# Altium schematic sheet p2_mcu_ble.SchDoc(p2_mcu_ble)
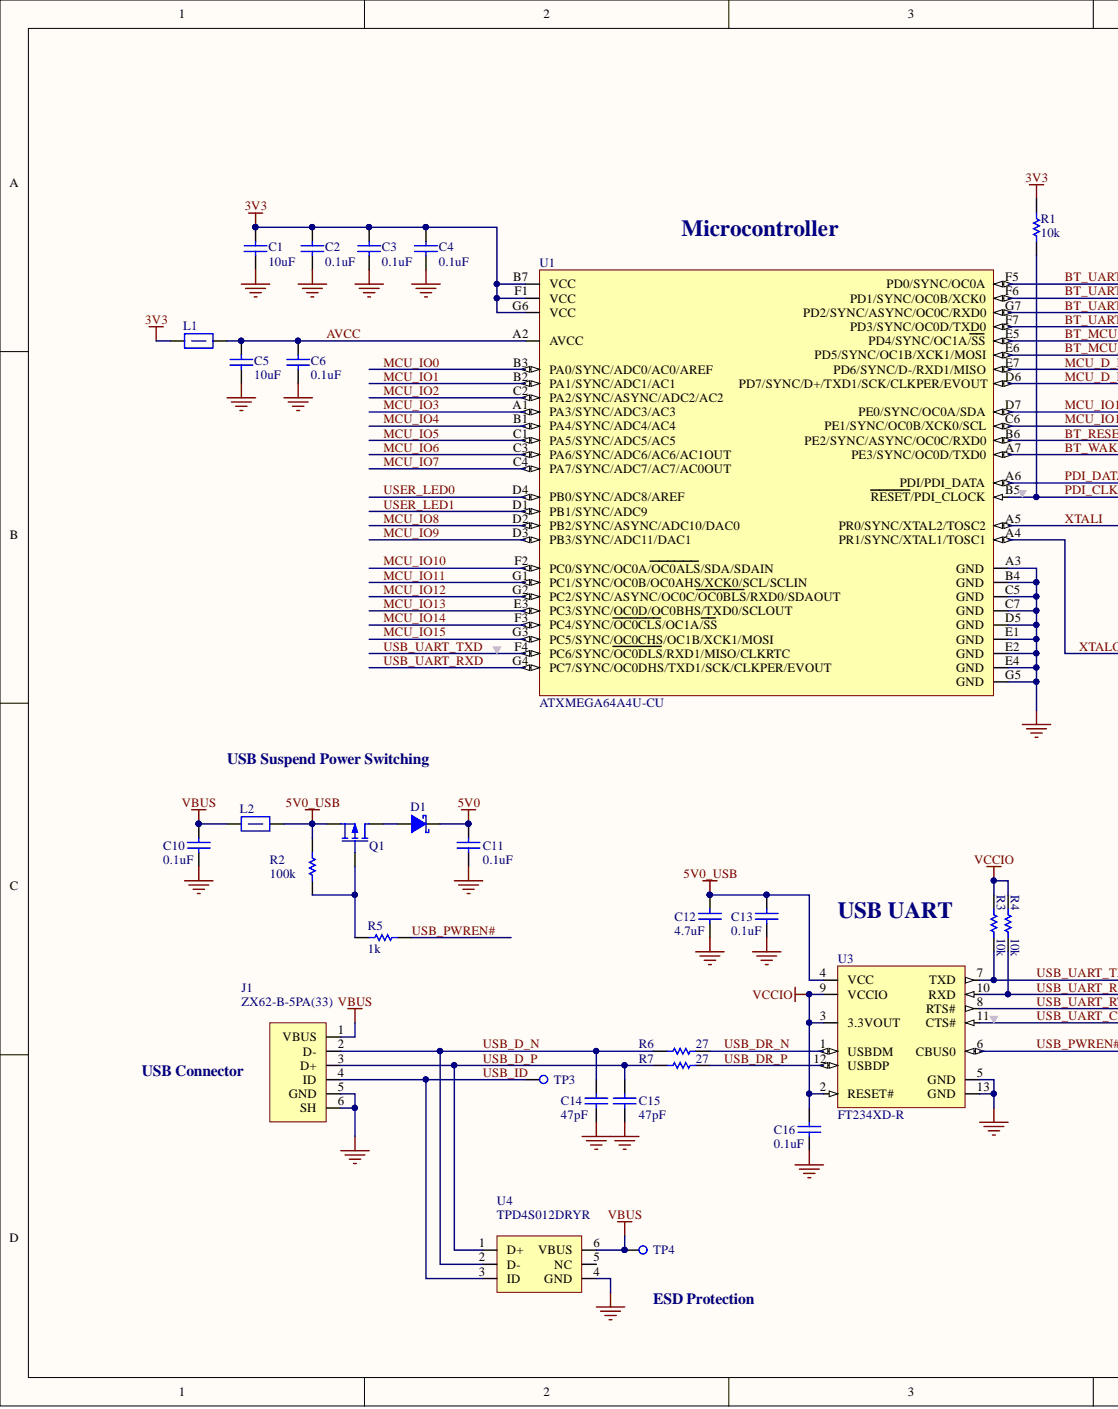
[diagram p0: p2_mcu_ble.SchDoc, left]
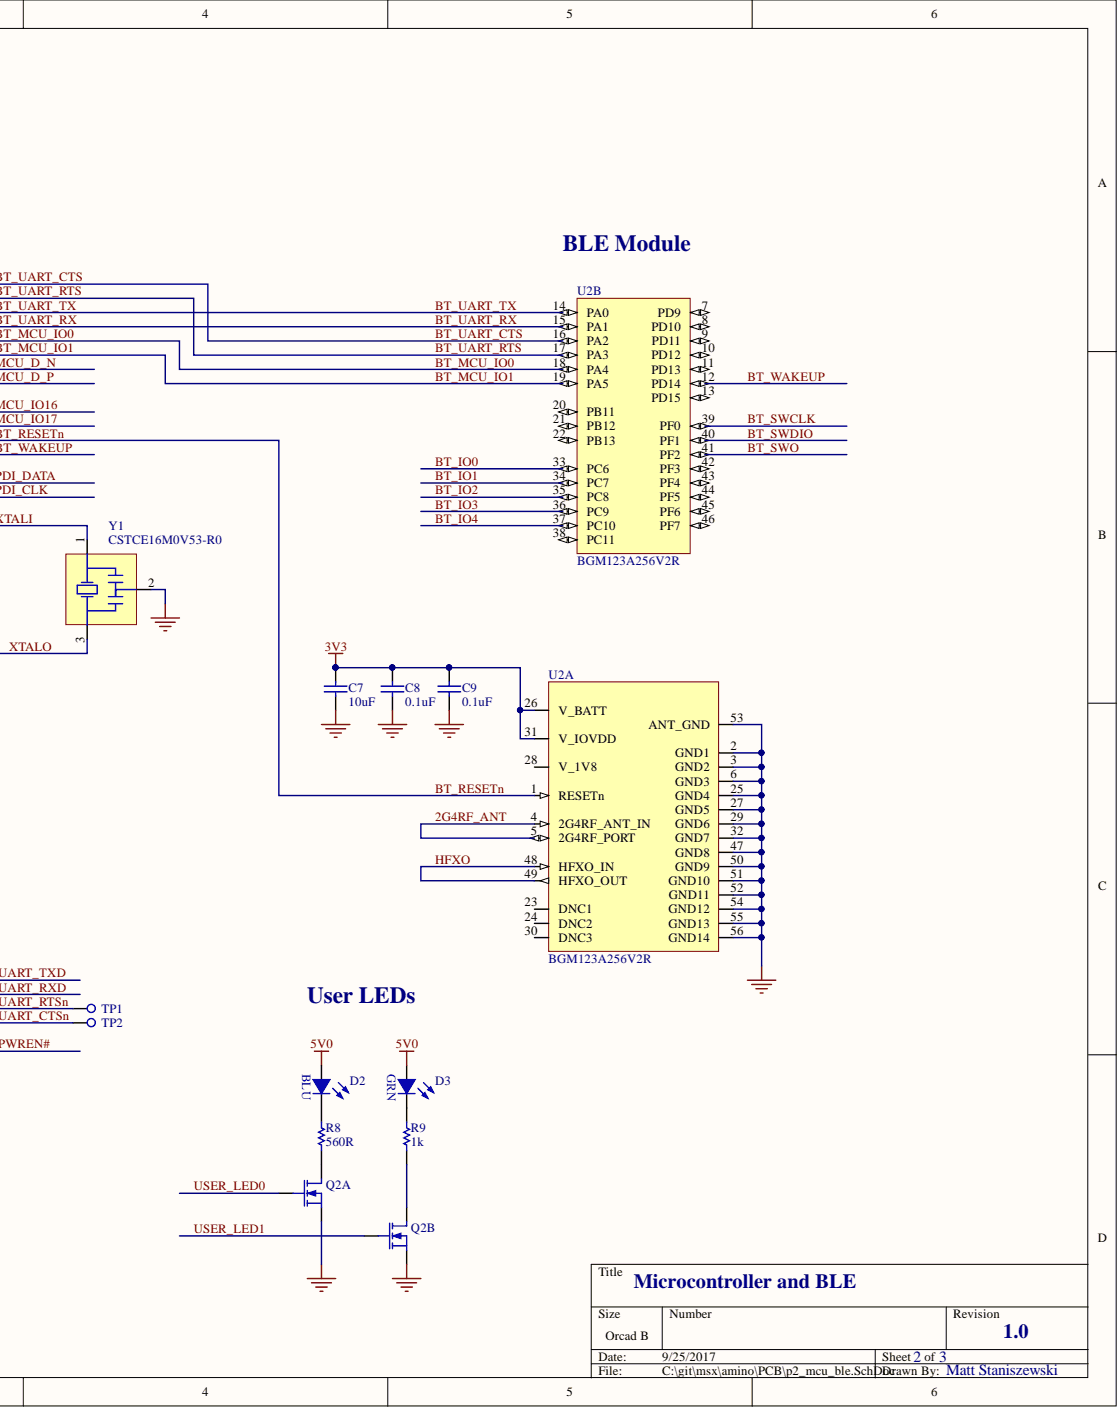
[diagram p1: p2_mcu_ble.SchDoc, right]

## Parts
C1  10uF  [C0603]
C2  0.1uF  [C0201]
C3  0.1uF  [C0201]
C4  0.1uF  [C0201]
C5  10uF  [C0603]
C6  0.1uF  [C0201]
C7  10uF  [C0603]
C8  0.1uF  [C0201]
C9  0.1uF  [C0201]
C10  0.1uF  [C0201]
C11  0.1uF  [C0201]
C12  4.7uF  [C0603]
C13  0.1uF  [C0201]
C14  47pF  [C0201]
C15  47pF  [C0201]
C16  0.1uF  [C0201]
D1  PMEG2020EPK,315  [NXP_DFN1608D-2]  (value not drawn)
D2  5988091107F  [LED0603]  (value not drawn)
D3  5988070107F  [LED0603]  (value not drawn)
J1  ZX62-B-5PA(33)  [HRS_MICRO_USB]
L1  BLM15PX601SN1D  [L0402]  (value not drawn)
L2  BLM15PX601SN1D  [L0402]  (value not drawn)
Q1  DMP21D0UFB4-7B  [DIO_X2-DFN1006-3]  (value not drawn)
Q2  PMDXB600UNE  [NXP_DFN1010B-6]  (value not drawn)  (parts A,B)
R1  10k  [R0201]
R2  100k  [R0201]
R3  10k  [R0201]
R4  10k  [R0201]
R5  1k  [R0201]
R6  27  [R0201]
R7  27  [R0201]
R8  560R  [R0201]
R9  1k  [R0201]
TP1  TP SMT 0.5MM  [TP_SMT_0.5MM]  (value not drawn)
TP2  TP SMT 0.5MM  [TP_SMT_0.5MM]  (value not drawn)
TP3  TP SMT 0.5MM  [TP_SMT_0.5MM]  (value not drawn)
TP4  TP SMT 0.5MM  [TP_SMT_0.5MM]  (value not drawn)
U1  ATXMEGA64A4U-CU  [MCP_49C2]
U2  BGM123A256V2R  [SIL_BGM123]  (parts A,B)
U3  FT234XD-R  [FTD_DFN12]
U4  TPD4S012DRYR  [TI_DRY]
Y1  CSTCE16M0V53-R0  [MUR_CSTCE_V]

## Nets
3V3: C1.2 C2.2 C3.2 C4.2 C7.2 C8.2 C9.2 L1.1 R1.2 U1.B7(VCC) U1.F1(VCC) U1.G6(VCC) U2.26(V_BATT) U2.31(V_IOVDD)
5V0: C11.2 D1.1 D2.2 D3.2
5V0_USB: C12.2 C13.2 L2.2 Q1.2 R2.2 U3.4(VCC)
GND: C1.1 C2.1 C3.1 C4.1 C5.1 C6.1 C7.1 C8.1 C9.1 C10.1 C11.1 C12.1 C13.1 C14.1 C15.1 C16.1 J1.5(GND) J1.6(SH) Q2.1 Q2.4 U1.A3(GND) U1.B4(GND) U1.C5(GND) U1.C7(GND) U1.D5(GND) U1.E1(GND) U1.E2(GND) U1.E4(GND) U1.G5(GND) U2.2(GND1) U2.3(GND2) U2.6(GND3) U2.25(GND4) U2.27(GND5) U2.29(GND6) U2.32(GND7) U2.47(GND8) U2.50(GND9) U2.51(GND10) U2.52(GND11) U2.53(ANT_GND) U2.54(GND12) U2.55(GND13) U2.56(GND14) U3.5(GND) U3.13(GND) U4.4(GND) Y1.2
VBUS: C10.2 J1.1(VBUS) L2.1 TP4.1 U4.6(VBUS)
VCCIO: C16.2 R3.2 R4.2 U3.2(RESET#) U3.3(3.3VOUT) U3.9(VCCIO)
2G4RF_ANT: U2.4(2G4RF_ANT_IN) U2.5(2G4RF_PORT)
AVCC: C5.2 C6.2 L1.2 U1.A2(AVCC)
BT_IO0: U2.33(PC6)
BT_IO1: U2.34(PC7)
BT_IO2: U2.35(PC8)
BT_IO3: U2.36(PC9)
BT_IO4: U2.37(PC10)
BT_MCU_IO0: U1.E5(PD4/SYNC/OC1A/SS) U2.18(PA4)
BT_MCU_IO1: U1.E6(PD5/SYNC/OC1B/XCK1/MOSI) U2.19(PA5)
BT_RESETn: U1.B6(PE2/SYNC/ASYNC/OC0C/RXD0) U2.1(RESETn)
BT_SWCLK: U2.39(PF0)
BT_SWDIO: U2.40(PF1)
BT_SWO: U2.41(PF2)
BT_UART_CTS: U1.F5(PD0/SYNC/OC0A) U2.16(PA2)
BT_UART_RTS: U1.F6(PD1/SYNC/OC0B/XCK0) U2.17(PA3)
BT_UART_RX: U1.F7(PD3/SYNC/OC0D/TXD0) U2.15(PA1)
BT_UART_TX: U1.G7(PD2/SYNC/ASYNC/OC0C/RXD0) U2.14(PA0)
BT_WAKEUP: U1.A7(PE3/SYNC/OC0D/TXD0) U2.12(PD14)
HFXO: U2.48(HFXO_IN) U2.49(HFXO_OUT)
MCU_D_N: U1.E7(PD6/SYNC/D-/RXD1/MISO)
MCU_D_P: U1.D6(PD7/SYNC/D+/TXD1/SCK/CLKPER/EVOUT)
MCU_IO0: U1.B3(PA0/SYNC/ADC0/AC0/AREF)
MCU_IO1: U1.B2(PA1/SYNC/ADC1/AC1)
MCU_IO2: U1.C2(PA2/SYNC/ASYNC/ADC2/AC2)
MCU_IO3: U1.A1(PA3/SYNC/ADC3/AC3)
MCU_IO4: U1.B1(PA4/SYNC/ADC4/AC4)
MCU_IO5: U1.C1(PA5/SYNC/ADC5/AC5)
MCU_IO6: U1.C3(PA6/SYNC/ADC6/AC6/AC1OUT)
MCU_IO7: U1.C4(PA7/SYNC/ADC7/AC7/AC0OUT)
MCU_IO8: U1.D2(PB2/SYNC/ASYNC/ADC10/DAC0)
MCU_IO9: U1.D3(PB3/SYNC/ADC11/DAC1)
MCU_IO10: U1.F2(PC0/SYNC/OC0A/OC0ALS/SDA/SDAIN)
MCU_IO11: U1.G1(PC1/SYNC/OC0B/OC0AHS/XCK0/SCL/SCLIN)
MCU_IO12: U1.G2(PC2/SYNC/ASYNC/OC0C/OC0BLS/RXD0/SDAOUT)
MCU_IO13: U1.E3(PC3/SYNC/OC0D/OC0BHS/TXD0/SCLOUT)
MCU_IO14: U1.F3(PC4/SYNC/OC0CLS/OC1A/SS)
MCU_IO15: U1.G3(PC5/SYNC/OC0CHS/OC1B/XCK1/MOSI)
MCU_IO16: U1.D7(PE0/SYNC/OC0A/SDA)
MCU_IO17: U1.C6(PE1/SYNC/OC0B/XCK0/SCL)
PDI_CLK: R1.1 U1.B5(RESET/PDI_CLOCK)
PDI_DATA: U1.A6(PDI/PDI_DATA)
USB_D_N: C14.2 J1.2(D-) R6.1 U4.2(D-)
USB_D_P: C15.2 J1.3(D+) R7.1 U4.1(D+)
USB_DR_N: R6.2 U3.1(USBDM)
USB_DR_P: R7.2 U3.12(USBDP)
USB_ID: J1.4(ID) TP3.1 U4.3(ID)
USB_PWREN#: R5.2 U3.6(CBUS0)
USB_UART_CTSn: TP2.1 U3.11(CTS#)
USB_UART_RTSn: TP1.1 U3.8(RTS#)
USB_UART_RXD: R4.1 U1.G4(PC7/SYNC/OC0DHS/TXD1/SCK/CLKPER/EVOUT) U3.10(RXD)
USB_UART_TXD: R3.1 U1.F4(PC6/SYNC/OC0DLS/RXD1/MISO/CLKRTC) U3.7(TXD)
USER_LED0: Q2.2 U1.D4(PB0/SYNC/ADC8/AREF)
USER_LED1: Q2.5 U1.D1(PB1/SYNC/ADC9)
XTALI: U1.A5(PR0/SYNC/XTAL2/TOSC2) Y1.1
XTALO: U1.A4(PR1/SYNC/XTAL1/TOSC1) Y1.3
NetD1_2: D1.2 Q1.3
NetD2_1: D2.1 R8.2
NetD3_1: D3.1 R9.2
NetQ1_1: Q1.1 R2.1 R5.1
NetQ2_3: Q2.3 R9.1
NetQ2_6: Q2.6 R8.1

Not connected: U2 7(PD9) 8(PD10) 9(PD11) 10(PD12) 11(PD13) 13(PD15) 20(PB11) 21(PB12) 22(PB13) 23(DNC1) 24(DNC2) 28(V_1V8) 30(DNC3) 38(PC11) 42(PF3) 43(PF4) 44(PF5) 45(PF6) 46(PF7); U4 5(NC)

## Library data of the parts
C1 C5 C7 = 10uF 25V 0603: CAP CER 10UF 25V X5R 0603; Manufacturer Part Number: GRM188R61E106MA73D; Manufacturer: Murata; Tolerance: 20%; Width: 0.031inch; Weight: 0.000222oz; Voltage Rating (DC): 25V; Voltage Rating: 25V; Voltage: 25V; Thickness: 0.031inch; Termination: SMD/SMT; Supplier Part Number 1: 490-7202-1-ND; Supplier 1: Digi-Key; Stock 1: 1088965; REACH SVHC: No SVHC; Pricing 1: 1=0.41, 10=0.285, 100=0.1708, 500=0.1281, 1000=0.1082 (USD); Packaging: Tape and Reel; Package Quantity: 1; Number of Pins: 2; Mount: Surface Mount; Min Operating Temperature: -55°C; Max Operating Temperature: 85°C; Library Name: Capacitors.SchLib; Length: 1.6mm; Lead Free: Lead Free; Height: 0.8mm; Dielectric: X5R; Depth: 0.8mm; Composition: Ceramic; ComponentLink5URL: https://datasheet.ciiva.com/14906/partnumbering-e-01-14906431.pdf; ComponentLink5Description: https://datasheet.ciiva.com/14906/partnumbering-e-01-14906431.pdf; ComponentLink4URL: https://datasheet.ciiva.com/14906/partnumbering-e-01-14906429.pdf; ComponentLink4Description: https://datasheet.ciiva.com/14906/partnumbering-e-01-14906429.pdf; ComponentLink3URL: https://datasheet.ciiva.com/22776/c02e-22776427.pdf; ComponentLink3Description: https://datasheet.ciiva.com/22776/c02e-22776427.pdf; ComponentLink2URL: https://datasheet.ciiva.com/22776/608985-22776426.pdf; ComponentLink2Description: https://datasheet.ciiva.com/22776/608985-22776426.pdf; ComponentLink1URL: https://datasheet.ciiva.com/22619/c02e-22619674.pdf; ComponentLink1Description: https://datasheet.ciiva.com/22619/c02e-22619674.pdf; Component Kind: Standard; Case Code (Metric): 1608; Case Code (Imperial): 0603; Case/Package: 0603; Capacitance: 10uF
C2-C4 C6 C8-C11 C13 C16 = 0.1uF 16V 0201: CAP CER 0.1UF 16V X5R 0201; Manufacturer Part Number: GRM033R61C104ME84D; Manufacturer: Murata; Tolerance: 20%; Capacitance: 1E-07f; Width: 0.3mm; Weight: 1.2E-05oz; Voltage Rating (DC): 16V; Voltage Rating: 16V; Thickness: 0.013inch; Termination: SMD/SMT; Supplier Part Number 1: 490-9986-1-ND; Supplier 1: Digi-Key; Stock 1: 660567; Pricing 1: 1=0.1, 10=0.029, 100=0.013, 500=0.0093, 1000=0.0073, 2500=0.0067, 5000=0.0061 (USD); Packaging: Tape and Reel; Package Quantity: 15000; Min Operating Temperature: -55°C; Max Operating Temperature: 85°C; Library Name: Capacitors.SchLib; Length: 0.024inch; Height: 0.3mm; Dielectric: X5R; ComponentLink1URL: http://www.mouser.com/ds/2/281/c02e-2905.pdf; ComponentLink1Description: http://www.mouser.com/ds/2/281/c02e-2905.pdf; Component Kind: Standard; Case Code (Metric): 0603; Case Code (Imperial): 0201; Case/Package: 0201
C12 = 4.7uF 16V 0603: CAP CER 4.7UF 16V X5R 0603; Manufacturer Part Number: GRM188R61C475KAAJD; Manufacturer: Murata; Tolerance: 10%; Material: Ceramic; Width: 0.8mm; Weight: 0.000222oz; Voltage Rating (DC): 16V; Voltage Rating: 16V; Thickness: 0.037inch; Termination: SMD/SMT; Supplier Part Number 1: 490-10481-1-ND; Supplier 1: Digi-Key; Stock 1: 135824; REACH SVHC: No SVHC; Pricing 1: 1=0.35, 10=0.238, 100=0.1351, 500=0.0953, 1000=0.0834 (USD); Packaging: Tape and Reel; Package Quantity: 4000; Min Operating Temperature: -55°C; Max Operating Temperature: 85°C; Library Name: Capacitors.SchLib; Length: 1.6mm; Lead Free: Lead Free; Height: 0.8mm; Dielectric: X5R; Depth: 0.8mm; ComponentLink1URL: https://datasheet.ciiva.com/14813/595grm188r61c475kaaj23-14813668.pdf; ComponentLink1Description: https://datasheet.ciiva.com/14813/595grm188r61c475kaaj23-14813668.pdf; Component Kind: Standard; Case Code (Metric): 1608; Case Code (Imperial): 0603; Case/Package: 0603; Capacitance: 4.7uF
C14 C15 = 47pF 25V 0201: CAP CER 47PF 25V C0G/NP0 0201; Manufacturer Part Number: GRM0335C1E470FA01D; Manufacturer: Murata; Tolerance: 1%; Width: 0.012inch; Voltage Rating (DC): 25V; Voltage Rating: 25V; Thickness: 0.013inch; Termination: SMD/SMT; Supplier Part Number 1: 490-11298-1-ND; Supplier 1: Digi-Key; Stock 1: 97008; Pricing 1: 1=0.1, 10=0.019, 100=0.0087, 500=0.0062, 1000=0.0049, 2500=0.0044, 5000=0.0041 (USD); Packaging: Tape and Reel; Package Quantity: 15000; Min Operating Temperature: -55°C; Max Operating Temperature: 125°C; Library Name: Capacitors.SchLib; Length: 0.024inch; ComponentLink1URL: https://datasheet.ciiva.com/29538/partnumbering-e-01-29538333.pdf; ComponentLink1Description: https://datasheet.ciiva.com/29538/partnumbering-e-01-29538333.pdf; Component Kind: Standard; Case Code (Metric): 0603; Case Code (Imperial): 0201; Case/Package: 0201; Capacitance: 47pF
D1 = PMEG2020EPK,315: DIODE SCHOTTKY 20V 2A 2DFN; Manufacturer: NXP Semiconductors; Reverse Recovery Time: 5ns; Peak Reverse Current: 70uA; Peak Non-Repetitive Surge Current: 5A; Natural Thermal Resistance: 20°C/W; Max Power Dissipation: 1.565W; Forward Voltage: 395mV; Element Configuration: Single; Supplier Part Number 1: 1727-1338-1-ND; Supplier 1: Digi-Key; Stock 1: 146420; Reverse Voltage (DC): 20V; Radiation Hardening: No; Pricing 1: 1=0.51, 10=0.387, 100=0.2411, 500=0.165, 1000=0.1269 (USD); Packaging: Tape and Reel; Package Quantity: 8000; Number of Pins: 2; Mount: Surface Mount; Min Operating Temperature: -55°C; Max Repetitive Reverse Voltage (Vrrm): 20V; Max Operating Temperature: 150°C; Max Forward Surge Current (Ifsm): 5A; Manufacturer Part Number: PMEG2020EPK,315; Library Name: Diodes.SchLib; Forward Current: 2A; ComponentLink4URL: https://datasheet.ciiva.com/25648/pmeg2020epk-25648600.pdf; ComponentLink4Description: https://datasheet.ciiva.com/25648/pmeg2020epk-25648600.pdf; ComponentLink3URL: https://datasheet.ciiva.com/24111/pmeg2020epk-268880-24111063.pdf; ComponentLink3Description: https://datasheet.ciiva.com/24111/pmeg2020epk-268880-24111063.pdf; ComponentLink2URL: https://datasheet.ciiva.com/29592/pmeg2020epk-29592901.pdf; ComponentLink2Description: https://datasheet.ciiva.com/29592/pmeg2020epk-29592901.pdf; ComponentLink1URL: https://datasheet.ciiva.com/29592/pmeg2020epk-29592900.pdf; ComponentLink1Description: https://datasheet.ciiva.com/29592/pmeg2020epk-29592900.pdf; Component Kind: Standard; Case/Package: SMD/SMT; Average Rectified Current: 2A
D2 = Blue 0603 LED: LED BLUE CLEAR 0603 SMD; Manufacturer Part Number: 598-8091-107F; Manufacturer: Dialight; Wavelength: 475nm; Viewing Angle: 140°; Test Current: 20mA; Power Dissipation: 70mW; Number of LEDs: 1; Luminous Intensity: 140mcd; Lens Style: Clear,Diffused,Colorless,Rectangular; Lens Colour: Clear,Colorless; Forward Voltage: 3.2V; Dominant Wavelength: 470nm; Width: 0.8mm; Supplier Part Number 1: 350-2037-1-ND; Supplier 1: Digi-Key; Stock 1: 42042; REACH SVHC: No SVHC; Radiation Hardening: No; Pricing 1: 1=0.75, 10=0.583, 25=0.4864, 100=0.4189, 250=0.374, 500=0.3216, 1000=0.2483 (USD); Packaging: Tape and Reel; Package Quantity: 4000; Number of Pins: 2; Number of Elements: 1; Mount: Surface Mount; Min Operating Temperature: -30°C; Max Operating Temperature: 100°C; Library Name: Diodes.SchLib; Lens Transparency: Clear; Length: 1.6mm; Lead Free: Lead Free; Illumination Colour: Blue; Height: 0.7mm; Forward Current: 20mA; Depth: 0.8mm; ComponentLink9URL: https://datasheet.ciiva.com/16400/smt-selector-guide-16400478.pdf; ComponentLink9Description: https://datasheet.ciiva.com/16400/smt-selector-guide-16400478.pdf; ComponentLink8URL: https://datasheet.ciiva.com/14603/70081992-14603963.pdf; ComponentLink8Description: https://datasheet.ciiva.com/14603/70081992-14603963.pdf; ComponentLink7URL: https://datasheet.ciiva.com/7970/25658-7970182.pdf; ComponentLink7Description: https://datasheet.ciiva.com/7970/25658-7970182.pdf; ComponentLink6URL: https://datasheet.ciiva.com/2111/598-series-0603-pkg-2111558.pdf; ComponentLink6Description: https://datasheet.ciiva.com/2111/598-series-0603-pkg-2111558.pdf; ComponentLink5URL: https://datasheet.ciiva.com/30240/mdei5980603-30240533.pdf; ComponentLink5Description: https://datasheet.ciiva.com/30240/mdei5980603-30240533.pdf; ComponentLink4URL: https://datasheet.ciiva.com/15965/mdei5980603-15965445.pdf; ComponentLink4Description: https://datasheet.ciiva.com/15965/mdei5980603-15965445.pdf; ComponentLink3URL: https://datasheet.ciiva.com/2111/598-series-0603-pkg-2111557.pdf; ComponentLink3Description: https://datasheet.ciiva.com/2111/598-series-0603-pkg-2111557.pdf; ComponentLink2URL: https://datasheet.ciiva.com/2111/598-series-0603-pkg-2111556.pdf; ComponentLink2Description: https://datasheet.ciiva.com/2111/598-series-0603-pkg-2111556.pdf; ComponentLink1URL: https://datasheet.ciiva.com/15118/getdatasheetpartid-194139-15118048.pdf; ComponentLink1Description: https://datasheet.ciiva.com/15118/getdatasheetpartid-194139-15118048.pdf; Component Kind: Standard; Colour: Blue; Case Code (Metric): 1608; Case Code (Imperial): 0603; Case/Package: 0603
D3 = Green 0603 LED: LED GREEN CLEAR 0603 SMD; Manufacturer Part Number: 598-8070-107F; Manufacturer: Dialight; Wavelength: 570nm; Viewing Angle: 140°; Test Current: 20mA; Power Dissipation: 60mW; Number of LEDs: 1; Luminous Intensity: 20mcd; Lens Style: Clear,Diffused,Colorless,Rectangular; Forward Voltage: 2V; Dominant Wavelength: 568nm; Width: 0.8mm; Supplier Part Number 1: 350-2035-1-ND; Supplier 1: Digi-Key; Stock 1: 147636; REACH SVHC: No SVHC; Radiation Hardening: No; Pricing 1: 1=0.45, 10=0.327, 25=0.2504, 100=0.1634, 250=0.1198, 500=0.1016, 1000=0.0835 (USD); Packaging: Tape and Reel; Package Quantity: 4000; Number of Pins: 2; Number of Elements: 1; Mount: Surface Mount; Min Operating Temperature: -30°C; Max Operating Temperature: 100°C; Library Name: Diodes.SchLib; Lens Colour: Clear; Length: 1.6mm; Lead Free: Lead Free; Illumination Colour: Green; Height: 0.7mm; Forward Current: 20mA; Depth: 0.8mm; ComponentLink13URL: https://datasheet.ciiva.com/16400/smt-selector-guide-16400463.pdf; ComponentLink13Description: https://datasheet.ciiva.com/16400/smt-selector-guide-16400463.pdf; ComponentLink12URL: https://datasheet.ciiva.com/22749/1484480-22749228.pdf; ComponentLink12Description: https://datasheet.ciiva.com/22749/1484480-22749228.pdf; ComponentLink11URL: https://datasheet.ciiva.com/15117/getdatasheetpartid-146438-15117836.pdf; ComponentLink11Description: https://datasheet.ciiva.com/15117/getdatasheetpartid-146438-15117836.pdf; ComponentLink10URL: https://datasheet.ciiva.com/30188/mdei5980603-30188139.pdf; ComponentLink10Description: https://datasheet.ciiva.com/30188/mdei5980603-30188139.pdf; ComponentLink9URL: https://datasheet.ciiva.com/15965/mdei5980603-15965419.pdf; ComponentLink9Description: https://datasheet.ciiva.com/15965/mdei5980603-15965419.pdf; ComponentLink8URL: https://datasheet.ciiva.com/14605/70081990-14605530.pdf; ComponentLink8Description: https://datasheet.ciiva.com/14605/70081990-14605530.pdf; ComponentLink7URL: https://datasheet.ciiva.com/2110/598-series-0603-pkg-2110167.pdf; ComponentLink7Description: https://datasheet.ciiva.com/2110/598-series-0603-pkg-2110167.pdf; ComponentLink6URL: https://datasheet.ciiva.com/2110/598-series-0603-pkg-2110166.pdf; ComponentLink6Description: https://datasheet.ciiva.com/2110/598-series-0603-pkg-2110166.pdf; ComponentLink5URL: https://datasheet.ciiva.com/1243/1484480-1243894.pdf; ComponentLink5Description: https://datasheet.ciiva.com/1243/1484480-1243894.pdf; ComponentLink4URL: https://datasheet.ciiva.com/2110/598-series-0603-pkg-2110165.pdf; ComponentLink4Description: https://datasheet.ciiva.com/2110/598-series-0603-pkg-2110165.pdf; ComponentLink3URL: https://datasheet.ciiva.com/1243/1484480-1243893.pdf; ComponentLink3Description: https://datasheet.ciiva.com/1243/1484480-1243893.pdf; ComponentLink2URL: https://datasheet.ciiva.com/11774/0900766b80ae2975-11774257.pdf; ComponentLink2Description: https://datasheet.ciiva.com/11774/0900766b80ae2975-11774257.pdf; ComponentLink1URL: https://datasheet.ciiva.com/4688/598-selectorguide-18902-4688880.pdf; ComponentLink1Description: https://datasheet.ciiva.com/4688/598-selectorguide-18902-4688880.pdf; Component Kind: Standard; Colour: Green; Case Code (Metric): 1608; Case Code (Imperial): 0603; Case/Package: 0603
J1 = USB Micro B: CONN RCPT USB MICRO B SMD R/A; Manufacturer: Hirose; Number of Contacts: 5; Mating Cycles: 10000; Gender: Receptacle; Current Rating: 1.8A; Supplier Part Number 1: H125270CT-ND; Supplier 1: Digi-Key; Stock 1: 63782; REACH SVHC: Unknown; Pricing 1: 1=0.84, 10=0.792, 25=0.7128, 50=0.6336, 100=0.6072, 250=0.5544, 500=0.528, 1000=0.4356 (USD); Packaging: Tape and Reel; Orientation: Right Angle; Manufacturer Part Number: ZX62-B-5PA(33); Library Name: Connectors.SchLib; Lead Free: Lead Free; Contact Plating: Gold; Contact Material: Copper; Connector Type: USB; ComponentLink1URL: http://www.farnell.com/datasheets/2060515.pdf; ComponentLink1Description: http://www.farnell.com/datasheets/2060515.pdf; Component Kind: Standard
L1 L2 = BLM15PX601SN1D: FERRITE BEAD 600 OHM 0402 1LN; Manufacturer: Murata; Tolerance: 25%; Test Frequency: 100MHz; Number of Lines: 1; Max DC Current: 900mA; Material: Steel; Impedance: 600R; DC Resistance (DCR): 230mR; Width: 0.5mm; Termination: SMD/SMT; Supplier Part Number 1: 490-9657-1-ND; Supplier 1: Digi-Key; Stock 1: 156023; Pricing 1: 1=0.15, 10=0.123, 25=0.0956, 50=0.0792, 100=0.0682, 250=0.0546, 500=0.0491, 1000=0.0409, 5000=0.0382 (USD); Packaging: Tape and Reel; Package Quantity: 10000; Number of Pins: 2; Number of Circuits: 1; Min Operating Temperature: -55°C; Max Operating Temperature: 125°C; Max Current Rating: 900mA; Manufacturer Part Number: BLM15PX601SN1D; Library Name: Inductors.SchLib; Length: 1mm; Lead Free: Lead Free; Height: 0.5mm; Current Rating: 900mA; ComponentLink2URL: https://datasheet.ciiva.com/41449/001172217-da-01-en-drossel-0402-600r-1-0x0-5mm-10000st-41449505.pdf; ComponentLink2Description: https://datasheet.ciiva.com/41449/001172217-da-01-en-drossel-0402-600r-1-0x0-5mm-10000st-41449505.pdf; ComponentLink1URL: https://datasheet.ciiva.com/41860/l0110e-41860698.pdf; ComponentLink1Description: https://datasheet.ciiva.com/41860/l0110e-41860698.pdf; Component Kind: Standard; Case Code (Metric): 1005; Case Code (Imperial): 0402; Case/Package: 0402
Q1 = DMP21D0UFB4-7B: MOSFET P-CH 20V 770MA 3DFN; Manufacturer: Diodes; Turn-On Delay Time: 7.1ns; Turn-Off Delay Time: 31.7ns; Rise Time: 8ns; Rds On Max: 495mR; Power Dissipation: 990mW; Number of Elements: 1; Input Capacitance: 80pF; Gate to Source Voltage (Vgs): 8V; Fall Time: 18.5ns; Element Configuration: Single; Drain to Source Resistance: 960mR; Drain to Source Breakdown Voltage: -20V; Continuous Drain Current (ID): 1.17A; Width: 0.65mm; Supplier Part Number 1: DMP21D0UFB4-7BDICT-ND; Supplier 1: Digi-Key; Stock 1: 167177; REACH SVHC: No SVHC; Radiation Hardening: No; Pricing 1: 1=0.4, 10=0.303, 100=0.1886, 500=0.129, 1000=0.0992 (USD); Packaging: Tape and Reel; Package Quantity: 1; Number of Pins: 3; Number of Channels: 1; Mount: Surface Mount; Min Operating Temperature: -55°C; Max Power Dissipation: 990mW; Max Operating Temperature: 150°C; Manufacturer Part Number: DMP21D0UFB4-7B; Library Name: ICs.SchLib; Length: 1.05mm; Lead Free: Lead Free; Height: 0.35mm; Drain to Source Voltage (Vdss): 20V; Contact Plating: Gold; ComponentLink6URL: https://datasheet.ciiva.com/13051/dmp21d0ufb4-13051690.pdf; ComponentLink6Description: https://datasheet.ciiva.com/13051/dmp21d0ufb4-13051690.pdf; ComponentLink5URL: https://datasheet.ciiva.com/30479/0900766b8115c367-30479913.pdf; ComponentLink5Description: https://datasheet.ciiva.com/30479/0900766b8115c367-30479913.pdf; ComponentLink4URL: https://datasheet.ciiva.com/30023/dmp21d0ufb4-30023815.pdf; ComponentLink4Description: https://datasheet.ciiva.com/30023/dmp21d0ufb4-30023815.pdf; ComponentLink3URL: https://datasheet.ciiva.com/6369/dmp21d0ufb4-6369015.pdf; ComponentLink3Description: https://datasheet.ciiva.com/6369/dmp21d0ufb4-6369015.pdf; ComponentLink2URL: https://datasheet.ciiva.com/6369/dmp21d0ufb4-6369014.pdf; ComponentLink2Description: https://datasheet.ciiva.com/6369/dmp21d0ufb4-6369014.pdf; ComponentLink1URL: https://datasheet.ciiva.com/6369/dmp21d0ufb4-6369012.pdf; ComponentLink1Description: https://datasheet.ciiva.com/6369/dmp21d0ufb4-6369012.pdf; Component Kind: Standard; Case/Package: DFN
Q2 = PMDXB600UNE: MOSFET 2N-CH 20V 0.6A 6DFN; Manufacturer: NXP Semiconductors; Threshold Voltage: 700mV; Rds On Max: 620mR; Max Power Dissipation: 265mW; Input Capacitance: 21.3pF; Continuous Drain Current (ID): 600mA; Supplier Part Number 1: 1727-1470-1-ND; Supplier 1: Digi-Key; Stock 1: 27879; REACH SVHC: No SVHC; Pricing 1: 1=0.65, 10=0.552, 100=0.4124, 500=0.324, 1000=0.2504 (USD); Packaging: Tape and Reel; Number of Pins: 6; Min Operating Temperature: -55°C; Max Operating Temperature: 150°C; Manufacturer Part Number: PMDXB600UNE; Library Name: ICs.SchLib; Drain to Source Voltage (Vdss): 20V; ComponentLink3URL: https://datasheet.ciiva.com/29589/pmdxb600une-29589933.pdf; ComponentLink3Description: https://datasheet.ciiva.com/29589/pmdxb600une-29589933.pdf; ComponentLink2URL: https://datasheet.ciiva.com/29589/pmdxb600une-29589932.pdf; ComponentLink2Description: https://datasheet.ciiva.com/29589/pmdxb600une-29589932.pdf; ComponentLink1URL: https://datasheet.ciiva.com/29589/pmdxb600une-29589931.pdf; ComponentLink1Description: https://datasheet.ciiva.com/29589/pmdxb600une-29589931.pdf; Component Kind: Standard; Case/Package: 600
R1 R3 R4 = 10k 0201: RES SMD 10K OHM 1% 1/20W 0201; Manufacturer Part Number: ERJ-1GEF1002C; Manufacturer: Panasonic ECG; Voltage Rating (DC): 15V; Voltage Rating: 25V; Temperature Coefficient: 200ppm/°C; Resistance: 10KR; Power Rating: 50mW; Width: 0.012inch; Tolerance: 1%; Termination: SMD/SMT; Supplier Part Number 1: P10.0KABCT-ND; Supplier 1: Digi-Key; Stock 1: 4709989; REACH SVHC: Unknown; Radiation Hardening: No; Pricing 1: 1=0.1, 10=0.059, 100=0.024, 1000=0.0108, 2500=0.0094, 5000=0.0077 (USD); Packaging: Tape and Reel; Package Quantity: 15000; Number of Terminations: 2; Mount: Surface Mount; Min Operating Temperature: -55°C; Military Standard: Not; Max Power Dissipation: 50mW; Max Operating Temperature: 125°C; Library Name: Resistors.SchLib; Length: 0.6mm; Lead Free: Lead Free; Height: 0.23mm; Depth: 0.3mm; Contact Plating: Tin; Composition: Thick Film; ComponentLink6URL: https://datasheet.ciiva.com/16770/70260683-16770095.pdf; ComponentLink6Description: https://datasheet.ciiva.com/16770/70260683-16770095.pdf; ComponentLink5URL: https://datasheet.ciiva.com/7830/1678796-7830952.pdf; ComponentLink5Description: https://datasheet.ciiva.com/7830/1678796-7830952.pdf; ComponentLink4URL: https://datasheet.ciiva.com/22785/1678796-22785991.pdf; ComponentLink4Description: https://datasheet.ciiva.com/22785/1678796-22785991.pdf; ComponentLink3URL: https://datasheet.ciiva.com/16775/70260683-16775527.pdf; ComponentLink3Description: https://datasheet.ciiva.com/16775/70260683-16775527.pdf; ComponentLink2URL: https://datasheet.ciiva.com/30111/aoa0000ce2-30111653.pdf; ComponentLink2Description: https://datasheet.ciiva.com/30111/aoa0000ce2-30111653.pdf; ComponentLink1URL: https://datasheet.ciiva.com/16427/erj-1gef1002c-16427252.pdf; ComponentLink1Description: https://datasheet.ciiva.com/16427/erj-1gef1002c-16427252.pdf; Component Kind: Standard; Case Code (Metric): 0603; Case Code (Imperial): 0201; Case/Package: 0201
R2 = 100k 0201: RES SMD 100K OHM 1% 1/20W 0201; Manufacturer Part Number: ERJ-1GEF1003C; Manufacturer: Panasonic ECG; Voltage Rating (DC): 15V; Voltage Rating: 25V; Temperature Coefficient: 200ppm/°C; Resistance: 100KR; Power Rating: 50mW; Width: 0.012inch; Tolerance: 1%; Termination: SMD/SMT; Supplier Part Number 1: P100KABCT-ND; Supplier 1: Digi-Key; Stock 1: 4025669; REACH SVHC: Unknown; Radiation Hardening: No; Pricing 1: 1=0.1, 10=0.059, 100=0.024, 1000=0.0108, 2500=0.0094, 5000=0.0077 (USD); Packaging: Tape and Reel; Package Quantity: 1; Number of Terminations: 2; Mount: Surface Mount; Min Operating Temperature: -55°C; Military Standard: Not; Max Power Dissipation: 50mW; Max Operating Temperature: 125°C; Library Name: Resistors.SchLib; Length: 0.6mm; Lead Free: Lead Free; Height: 0.01inch; Depth: 0.3mm; Contact Plating: Tin; Composition: Thick Film; ComponentLink8URL: https://datasheet.ciiva.com/30087/aoa0000ce2-30087131.pdf; ComponentLink8Description: https://datasheet.ciiva.com/30087/aoa0000ce2-30087131.pdf; ComponentLink7URL: https://datasheet.ciiva.com/28514/1694929-28514010.pdf; ComponentLink7Description: https://datasheet.ciiva.com/28514/1694929-28514010.pdf; ComponentLink6URL: https://datasheet.ciiva.com/16782/70260683-16782969.pdf; ComponentLink6Description: https://datasheet.ciiva.com/16782/70260683-16782969.pdf; ComponentLink5URL: https://datasheet.ciiva.com/16205/erj-1gef1003c-16205689.pdf; ComponentLink5Description: https://datasheet.ciiva.com/16205/erj-1gef1003c-16205689.pdf; ComponentLink4URL: https://datasheet.ciiva.com/7830/1678796-7830953.pdf; ComponentLink4Description: https://datasheet.ciiva.com/7830/1678796-7830953.pdf; ComponentLink3URL: https://datasheet.ciiva.com/2439/erj-g-r-e-rev08-2439267.pdf; ComponentLink3Description: https://datasheet.ciiva.com/2439/erj-g-r-e-rev08-2439267.pdf; ComponentLink2URL: https://datasheet.ciiva.com/2439/erj-g-r-e-rev08-2439266.pdf; ComponentLink2Description: https://datasheet.ciiva.com/2439/erj-g-r-e-rev08-2439266.pdf; ComponentLink1URL: https://datasheet.ciiva.com/2439/erj-g-r-e-rev08-2439265.pdf; ComponentLink1Description: https://datasheet.ciiva.com/2439/erj-g-r-e-rev08-2439265.pdf; Component Kind: Standard; Case Code (Metric): 0603; Case Code (Imperial): 0201; Case/Package: 0201
R5 R9 = 1k 0201: RES SMD 1K OHM 1% 1/20W 0201; Manufacturer Part Number: ERJ-1GEF1001C; Manufacturer: Panasonic ECG; Voltage Rating (DC): 15V; Voltage Rating: 25V; Temperature Coefficient: 200ppm/°C; Resistance: 1KR; Power Rating: 50mW; Width: 0.012inch; Tolerance: 1%; Termination: SMD/SMT; Supplier Part Number 1: P1.00KABCT-ND; Supplier 1: Digi-Key; Stock 1: 3138057; REACH SVHC: Unknown; Radiation Hardening: No; Pricing 1: 1=0.1, 10=0.059, 100=0.024, 1000=0.0108, 2500=0.0094, 5000=0.0077 (USD); Packaging: Tape and Reel; Package Quantity: 15000; Number of Terminations: 2; Mount: Surface Mount; Min Operating Temperature: -55°C; Military Standard: Not; Max Power Dissipation: 50mW; Max Operating Temperature: 125°C; Library Name: Resistors.SchLib; Length: 0.6mm; Lead Free: Lead Free; Height: 0.23mm; Depth: 0.3mm; Contact Plating: Tin; Composition: Thick Film; ComponentLink6URL: https://datasheet.ciiva.com/16782/70260683-16782463.pdf; ComponentLink6Description: https://datasheet.ciiva.com/16782/70260683-16782463.pdf; ComponentLink5URL: https://datasheet.ciiva.com/7942/1678796-7942463.pdf; ComponentLink5Description: https://datasheet.ciiva.com/7942/1678796-7942463.pdf; ComponentLink4URL: https://datasheet.ciiva.com/30155/aoa0000ce2-30155870.pdf; ComponentLink4Description: https://datasheet.ciiva.com/30155/aoa0000ce2-30155870.pdf; ComponentLink3URL: https://datasheet.ciiva.com/16199/erj-1gef1001c-16199565.pdf; ComponentLink3Description: https://datasheet.ciiva.com/16199/erj-1gef1001c-16199565.pdf; ComponentLink2URL: https://datasheet.ciiva.com/16763/70260683-16763516.pdf; ComponentLink2Description: https://datasheet.ciiva.com/16763/70260683-16763516.pdf; ComponentLink1URL: https://datasheet.ciiva.com/28532/1694929-28532042.pdf; ComponentLink1Description: https://datasheet.ciiva.com/28532/1694929-28532042.pdf; Component Kind: Standard; Case Code (Metric): 0603; Case Code (Imperial): 0201; Case/Package: 0201
R6 R7 = 27R 0201: RES SMD 27 OHM 1% 1/20W 0201; Manufacturer Part Number: ERJ-1GEF27R0C; Manufacturer: Panasonic ECG; Voltage Rating (DC): 15V; Voltage Rating: 25V; Temperature Coefficient: 200ppm/°C; Resistance: 27R; Power Rating: 50mW; Width: 0.012inch; Tolerance: 1%; Supplier Part Number 1: P27.0ABCT-ND; Supplier 1: Digi-Key; Stock 1: 66085; Radiation Hardening: No; Pricing 1: 1=0.1, 10=0.059, 100=0.024, 1000=0.0108, 2500=0.0094, 5000=0.0077 (USD); Packaging: Tape and Reel; Package Quantity: 1; Number of Terminations: 2; Mount: Surface Mount; Min Operating Temperature: -55°C; Military Standard: Not; Max Power Dissipation: 50mW; Max Operating Temperature: 125°C; Library Name: Resistors.SchLib; Length: 0.6mm; Lead Free: Lead Free; Height: 0.01inch; Depth: 0.3mm; Contact Plating: Tin; Composition: Thick Film; ComponentLink4URL: https://datasheet.ciiva.com/30072/aoa0000ce2-30072301.pdf; ComponentLink4Description: https://datasheet.ciiva.com/30072/aoa0000ce2-30072301.pdf; ComponentLink3URL: https://datasheet.ciiva.com/2526/erj-g-r-e-rev08-2526741.pdf; ComponentLink3Description: https://datasheet.ciiva.com/2526/erj-g-r-e-rev08-2526741.pdf; ComponentLink2URL: https://datasheet.ciiva.com/2526/erj-g-r-e-rev08-2526740.pdf; ComponentLink2Description: https://datasheet.ciiva.com/2526/erj-g-r-e-rev08-2526740.pdf; ComponentLink1URL: https://datasheet.ciiva.com/2526/erj-g-r-e-rev08-2526739.pdf; ComponentLink1Description: https://datasheet.ciiva.com/2526/erj-g-r-e-rev08-2526739.pdf; Component Kind: Standard; Case Code (Metric): 0603; Case Code (Imperial): 0201; Case/Package: 0201
R8 = 560R 0201: RES SMD 560 OHM 1% 1/20W 0201; Manufacturer Part Number: ERJ-1GEF5600C; Manufacturer: Panasonic ECG; Voltage Rating (DC): 15V; Voltage Rating: 25V; Temperature Coefficient: 200ppm/°C; Power Rating: 50mW; Width: 0.3mm; Tolerance: 1%; Supplier Part Number 1: P560ABCT-ND; Supplier 1: Digi-Key; Stock 1: 11988; Resistance: 560R; Radiation Hardening: No; Pricing 1: 1=0.1, 10=0.059, 100=0.024, 1000=0.0108, 2500=0.0094, 5000=0.0077 (USD); Packaging: Tape and Reel; Package Quantity: 15000; Number of Terminations: 2; Mount: Surface Mount; Min Operating Temperature: -55°C; Military Standard: Not; Max Power Dissipation: 50mW; Max Operating Temperature: 125°C; Library Name: Resistors.SchLib; Length: 0.6mm; Lead Free: Lead Free; Height: 0.01inch; Depth: 0.3mm; Contact Plating: Tin; Composition: Thick Film; ComponentLink3URL: https://datasheet.ciiva.com/2440/erj-g-r-e-rev08-2440590.pdf; ComponentLink3Description: https://datasheet.ciiva.com/2440/erj-g-r-e-rev08-2440590.pdf; ComponentLink2URL: https://datasheet.ciiva.com/2440/erj-g-r-e-rev08-2440589.pdf; ComponentLink2Description: https://datasheet.ciiva.com/2440/erj-g-r-e-rev08-2440589.pdf; ComponentLink1URL: https://datasheet.ciiva.com/2440/erj-g-r-e-rev08-2440588.pdf; ComponentLink1Description: https://datasheet.ciiva.com/2440/erj-g-r-e-rev08-2440588.pdf; Component Kind: Standard; Case Code (Metric): 0603; Case Code (Imperial): 0201; Case/Package: 0201
TP1-TP4 = TP SMT 0.5MM: 0.5mm SMT Test Point; Library Name: Misc.SchLib; Component Kind: Standard
U1 = ATXMEGA64A4U-CU: IC MCU 8BIT 64KB FLASH 49VFBGA; Manufacturer Part Number: ATXMEGA64A4U-CUR; Manufacturer: Microchip / Atmel; Watchdog Timer: Yes; RAM Size: 4KB; Peripherals: Brown-out Detect/Reset,POR,PWM,WDT,DMA; Oscillator Type: Internal; Number of Timers/Counters: 5; Number of Programmable I/O: 34; Min Supply Voltage: 1.6V; Max Supply Voltage: 3.6V; Max Frequency: 32MHz; Interface: I2C,SPI,USB,IrDA,UART,USART; Data Bus Width: 8b; Supplier Part Number 1: ATXMEGA64A4U-CURCT-ND; Supplier 1: Digi-Key; Stock 1: 4285; Pricing 1: 1=4.65, 25=4.2632, 100=3.864 (USD); Packaging: Tape and Reel; Package Quantity: 2000; Mount: Surface Mount; Min Operating Temperature: -40°C; Memory Type: FLASH; Memory Size: 64KB; Max Operating Temperature: 85°C; Library Name: ICs.SchLib; Frequency: 32MHz; ComponentLink1URL: https://datasheet.ciiva.com/24017/atmel-8387-8-and16-bit-avr-microcontroller-xmega-a-259135-24017304.pdf; ComponentLink1Description: https://datasheet.ciiva.com/24017/atmel-8387-8-and16-bit-avr-microcontroller-xmega-a-259135-24017304.pdf; Component Kind: Standard
U2 = BGM123A256V2R: BGM123 BLUETOOTH SIP MODULE, 3 D; Manufacturer: Silicon Labs; Supplier Part Number 1: 336-3808-1-ND; Supplier 1: Digi-Key; Stock 1: 0; Manufacturer Part Number: BGM123A256V2R; Library Name: ICs.SchLib; Component Kind: Standard
U3 = FT234XD-R: IC USB SERIAL BASIC UART 12DFN; Manufacturer: FTDI; Supply Voltage: 5V; Operating Supply Current: 8mA; Number of Transceivers: 1; Min Supply Voltage: 2.97V; Max Supply Voltage: 5.5V; Interface: USB,UART; Data Rate: 3Mbps; Width: 3mm; Supplier Part Number 1: 768-1178-1-ND; Supplier 1: Digi-Key; Stock 1: 78937; REACH SVHC: No SVHC; Pricing 1: 1=2.04, 25=1.97, 100=1.9, 250=1.71, 500=1.54, 1000=1.46, 2500=1.4214 (USD); Packaging: Tape and Reel; Package Quantity: 5000; Number of Ports: 1; Number of Pins: 12; Min Operating Temperature: -40°C; Max Operating Temperature: 85°C; Manufacturer Part Number: FT234XD-R; Library Name: ICs.SchLib; Length: 3mm; Lead Free: Lead Free; Height: 0.75mm; ComponentLink4URL: https://datasheet.ciiva.com/46410/1812674-46410091.pdf; ComponentLink4Description: https://datasheet.ciiva.com/46410/1812674-46410091.pdf; ComponentLink3URL: https://datasheet.ciiva.com/15013/ds-ft234xd-15013689.pdf; ComponentLink3Description: https://datasheet.ciiva.com/15013/ds-ft234xd-15013689.pdf; ComponentLink2URL: https://datasheet.ciiva.com/15013/ds-ft234xd-15013688.pdf; ComponentLink2Description: https://datasheet.ciiva.com/15013/ds-ft234xd-15013688.pdf; ComponentLink1URL: https://datasheet.ciiva.com/15013/ds-ft234xd-15013687.pdf; ComponentLink1Description: https://datasheet.ciiva.com/15013/ds-ft234xd-15013687.pdf; Component Kind: Standard; Case/Package: DFN
U4 = TPD4S012DRYR: IC 4CH ESD SOLUTION W/CLAMP 6SON; Manufacturer: Texas Instruments; Working Voltage: 19V; Test Current: 1mA; Supply Voltage: 950mV; Polarity: Unidirectional; Peak Pulse Power: 60W; Peak Pulse Current: 3A; Number of Circuits: 4; Min Breakdown Voltage: 20V; Interface: USB; ESD Protection: Yes; Capacitance: 15pF; Breakdown Voltage: 24V; Width: 1.05mm; Termination: SMD/SMT; Supplier Part Number 1: 296-24244-1-ND; Supplier 1: Digi-Key; Stock 1: 85253; REACH SVHC: No SVHC; Radiation Hardening: No; Pricing 1: 1=0.77, 10=0.675, 100=0.5171, 500=0.4088, 1000=0.3271 (USD); Packaging: Tape and Reel; Package Quantity: 5000; Number of Pins: 6; Number of Channels: 4; Min Operating Temperature: -40°C; Max Power Dissipation: 60W; Max Operating Temperature: 85°C; Manufacturer Part Number: TPD4S012DRYR; Library Name: ICs.SchLib; Length: 1.45mm; Lead Free: Lead Free; Height: 0.55mm; Direction: Unidirectional; Depth: 1mm; ComponentLink11URL: https://datasheet.ciiva.com/361/tpd4s012-361279.pdf; ComponentLink11Description: https://datasheet.ciiva.com/361/tpd4s012-361279.pdf; ComponentLink10URL: https://datasheet.ciiva.com/22621/1857625-22621486.pdf; ComponentLink10Description: https://datasheet.ciiva.com/22621/1857625-22621486.pdf; ComponentLink9URL: https://datasheet.ciiva.com/15104/getdatasheetpartid-293634-15104046.pdf; ComponentLink9Description: https://datasheet.ciiva.com/15104/getdatasheetpartid-293634-15104046.pdf; ComponentLink8URL: https://datasheet.ciiva.com/361/tpd4s012-361278.pdf; ComponentLink8Description: https://datasheet.ciiva.com/361/tpd4s012-361278.pdf; ComponentLink7URL: https://datasheet.ciiva.com/1268/tpd4s012-1268381.pdf; ComponentLink7Description: https://datasheet.ciiva.com/1268/tpd4s012-1268381.pdf; ComponentLink6URL: https://datasheet.ciiva.com/28496/tpd4s012-28496124.pdf; ComponentLink6Description: https://datasheet.ciiva.com/28496/tpd4s012-28496124.pdf; ComponentLink5URL: https://datasheet.ciiva.com/46381/1857625-46381802.pdf; ComponentLink5Description: https://datasheet.ciiva.com/46381/1857625-46381802.pdf; ComponentLink4URL: https://datasheet.ciiva.com/1271/1719848-1271898.pdf; ComponentLink4Description: https://datasheet.ciiva.com/1271/1719848-1271898.pdf; ComponentLink3URL: https://datasheet.ciiva.com/25956/tpd4s012-25956897.pdf; ComponentLink3Description: https://datasheet.ciiva.com/25956/tpd4s012-25956897.pdf; ComponentLink2URL: https://datasheet.ciiva.com/4479/slvs928a-120928-4479536.pdf; ComponentLink2Description: https://datasheet.ciiva.com/4479/slvs928a-120928-4479536.pdf; ComponentLink1URL: https://datasheet.ciiva.com/361/tpd4s012-361280.pdf; ComponentLink1Description: https://datasheet.ciiva.com/361/tpd4s012-361280.pdf; Component Kind: Standard
Y1 = 16MHz Resonator: CER RES 16.0000MHZ 15PF SMD; Manufacturer: Murata; Tolerance: 0.5%; Self Resonant Frequency: 16MHz; Impedance: 40R; Frequency Stability: 0.3%; Width: 0.051inch; Termination: SMD/SMT; Supplier Part Number 1: 490-1198-1-ND; Supplier 1: Digi-Key; Stock 1: 104178; REACH SVHC: No SVHC; Pricing 1: 1=0.5, 10=0.42, 50=0.3776, 100=0.3357, 500=0.3189, 1000=0.2686 (USD); Packaging: Tape and Reel; Package Quantity: 3000; Number of Pins: 3; Min Operating Temperature: -20°C; Max Operating Temperature: 80°C; Manufacturer Part Number: CSTCE16M0V53-R0; Load Capacitance: 15pF; Library Name: Oscillators.SchLib; Length: 0.126inch; Lead Free: Lead Free; Height: 0.039inch; Frequency Tolerance: 0.5%; Frequency: 16MHz; Contact Plating: Gold; Composition: Ceramic; ComponentLink7URL: https://datasheet.ciiva.com/16216/l0430e-16216847.pdf; ComponentLink7Description: https://datasheet.ciiva.com/16216/l0430e-16216847.pdf; ComponentLink6URL: https://datasheet.ciiva.com/14132/ceralock-eng-bro-14132735.pdf; ComponentLink6Description: https://datasheet.ciiva.com/14132/ceralock-eng-bro-14132735.pdf; ComponentLink5URL: https://datasheet.ciiva.com/884/p16e-884479.pdf; ComponentLink5Description: https://datasheet.ciiva.com/884/p16e-884479.pdf; ComponentLink4URL: https://datasheet.ciiva.com/884/p16e-884478.pdf; ComponentLink4Description: https://datasheet.ciiva.com/884/p16e-884478.pdf; ComponentLink3URL: https://datasheet.ciiva.com/1361/1506176-1361293.pdf; ComponentLink3Description: https://datasheet.ciiva.com/1361/1506176-1361293.pdf; ComponentLink2URL: https://datasheet.ciiva.com/884/p16e-884477.pdf; ComponentLink2Description: https://datasheet.ciiva.com/884/p16e-884477.pdf; ComponentLink1URL: https://datasheet.ciiva.com/18183/getdatasheetpartid-152969-18183272.pdf; ComponentLink1Description: https://datasheet.ciiva.com/18183/getdatasheetpartid-152969-18183272.pdf; Component Kind: Standard; Case/Package: SMD/SMT; Capacitance: 15pF
